# Altium schematic sheet Power.SchDoc
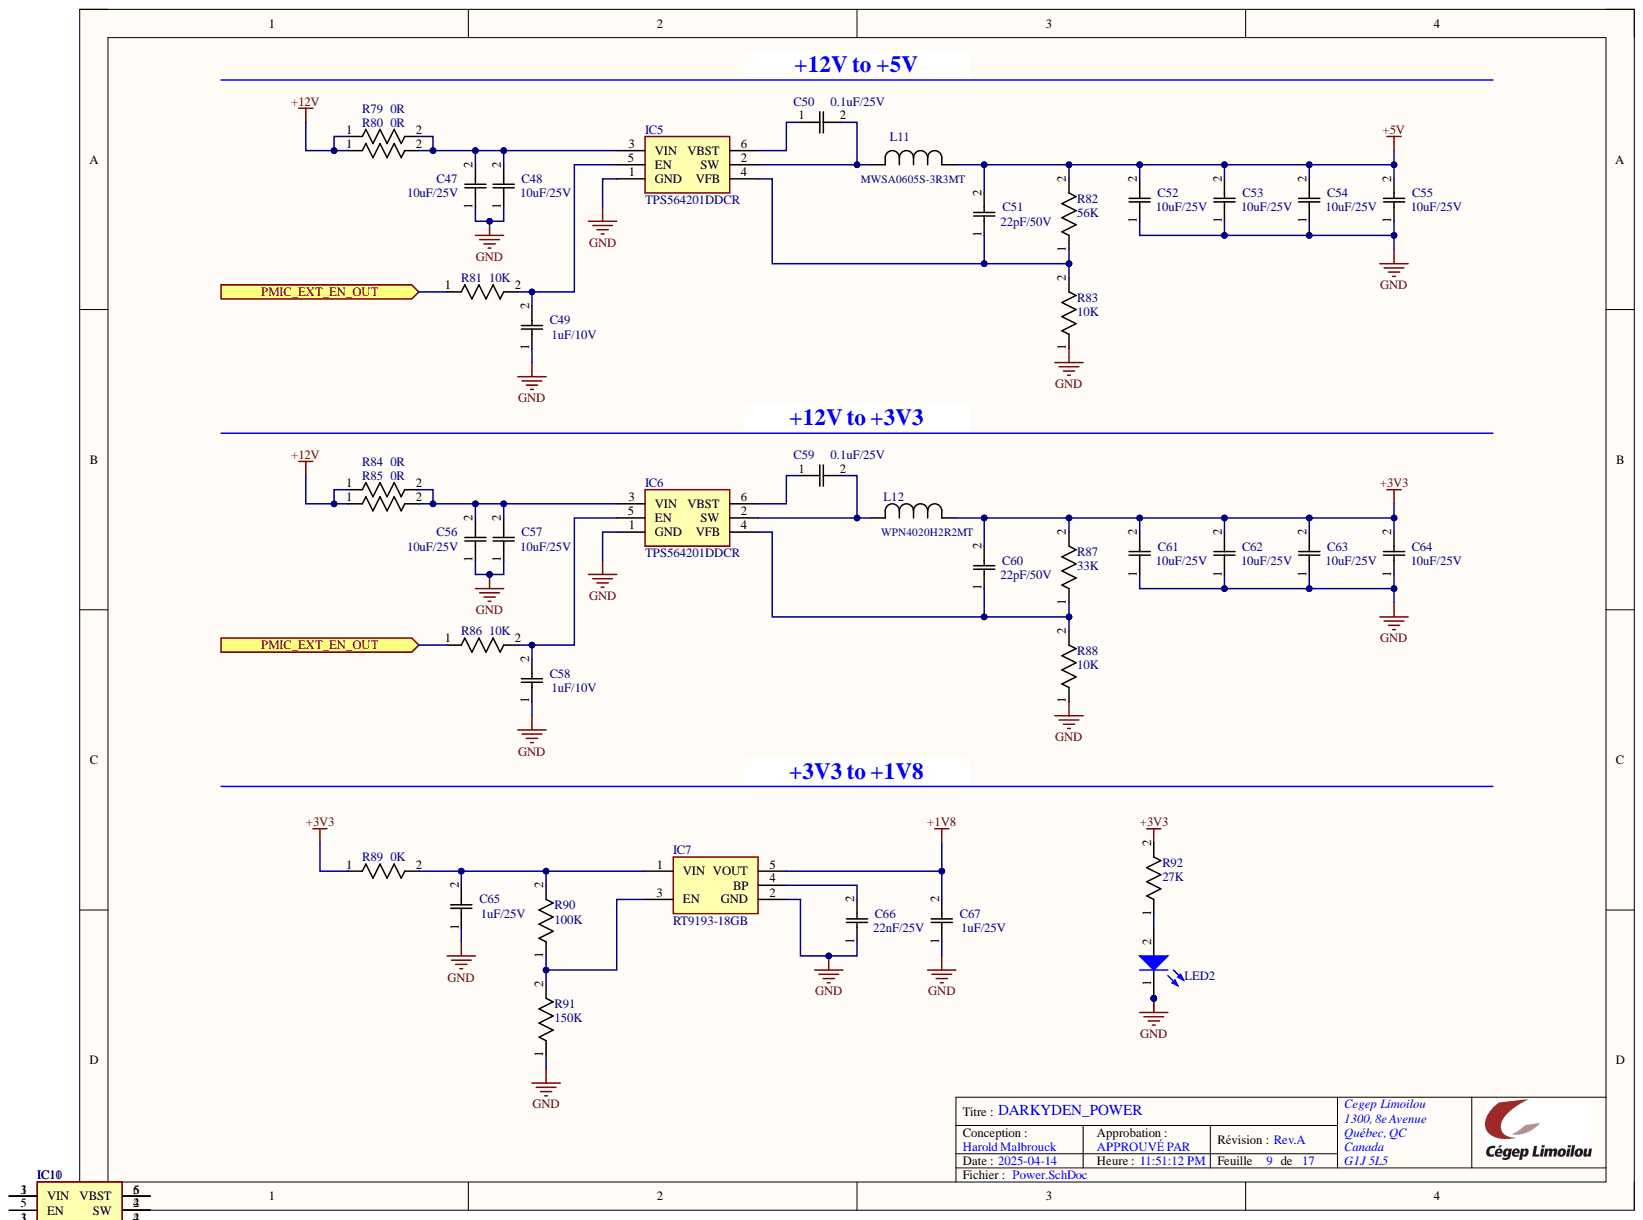
[diagram p0: Power.SchDoc]

## Parts
C47  10uF/25V  [CAP_CC0805_YAG]
C48  10uF/25V  [CAP_CC0805_YAG]
C49  1uF/10V  [CAP_CC0805_YAG]
C50  0.1uF/25V  [CAP_CC0805_YAG]
C51  22pF/50V  [CAP_CC0805_YAG]
C52  10uF/25V  [CAP_CC0805_YAG]
C53  10uF/25V  [CAP_CC0805_YAG]
C54  10uF/25V  [CAP_CC0805_YAG]
C55  10uF/25V  [CAP_CC0805_YAG]
C56  10uF/25V  [CAP_CC0805_YAG]
C57  10uF/25V  [CAP_CC0805_YAG]
C58  1uF/10V  [CAP_CC0805_YAG]
C59  0.1uF/25V  [CAP_CC0805_YAG]
C60  22pF/50V  [CAP_CC0805_YAG]
C61  10uF/25V  [CAP_CC0805_YAG]
C62  10uF/25V  [CAP_CC0805_YAG]
C63  10uF/25V  [CAP_CC0805_YAG]
C64  10uF/25V  [CAP_CC0805_YAG]
C65  1uF/25V  [CAP_CC0805_YAG]
C66  22nF/25V  [CAP_CC0805_YAG]
C67  1uF/25V  [CAP_CC0805_YAG]
IC5  TPS564201DDCR  [SOT95P280X110-6N]
IC6  TPS564201DDCR  [SOT95P280X110-6N]
IC7  RT9193-18GB  [SOT94P279X130-5N]
IC10  TPS564201DDCR  [SOT95P280X110-6N]
IC11  RT9193-18GB  [SOT94P279X130-5N]
L11  MWSA0605S-3R3MT  [IND_MWSA0603S_SNL]
L12  WPN4020H2R2MT  [IND_WPN4020H_SNL]
LED2  Blue, 3.2V, 140°  [WL-SMCD_0603]  (value not drawn)
R79  0R  [RES_0816_SUS]
R80  0R  [RES_0816_SUS]
R81  10K  [RES_0816_SUS]
R82  56K  [RES_0816_SUS]
R83  10K  [RES_0816_SUS]
R84  0R  [RES_0816_SUS]
R85  0R  [RES_0816_SUS]
R86  10K  [RES_0816_SUS]
R87  33K  [RES_0816_SUS]
R88  10K  [RES_0816_SUS]
R89  0K  [RES_0816_SUS]
R90  100K  [RES_0816_SUS]
R91  150K  [RES_0816_SUS]
R92  27K  [RES_0816_SUS]

## Ports
PMIC_EXT_EN_OUT

## Nets
+1V8: C67.2 IC7.5(VOUT)
+3V3: C60.2 C61.2 C62.2 C63.2 C64.2 L12.1 R87.2 R89.1 R92.2
+5V: C51.2 C52.2 C53.2 C54.2 C55.2 L11.1 R82.2
+12V: R79.1 R80.1 R84.1 R85.1
GND: C47.1 C48.1 C49.1 C52.1 C53.1 C54.1 C55.1 C56.1 C57.1 C58.1 C61.1 C62.1 C63.1 C64.1 C65.1 C66.1 C67.1 IC5.1(GND) IC6.1(GND) IC7.2(GND) LED2.1 R83.1 R88.1 R91.1
PMIC_EXT_EN_OUT: R81.1 R86.1 ; port PMIC_EXT_EN_OUT
NetC47_2: C47.2 C48.2 IC5.3(VIN) R79.2 R80.2
NetC49_2: C49.2 IC5.5(EN) R81.2
NetC50_1: C50.1 IC5.6(VBST)
NetC50_2: C50.2 IC5.2(SW) L11.2
NetC51_1: C51.1 IC5.4(VFB) R82.1 R83.2
NetC56_2: C56.2 C57.2 IC6.3(VIN) R84.2 R85.2
NetC58_2: C58.2 IC6.5(EN) R86.2
NetC59_1: C59.1 IC6.6(VBST)
NetC59_2: C59.2 IC6.2(SW) L12.2
NetC60_1: C60.1 IC6.4(VFB) R87.1 R88.2
NetC65_2: C65.2 IC7.1(VIN) R89.2 R90.2
NetC66_2: C66.2 IC7.4(BP)
NetIC7_3: IC7.3(EN) R90.1 R91.2
NetIC10_1: IC10.1 IC11.3
NetIC10_2: IC10.2(BP) IC11.4(BP)
NetIC10_3: IC10.3(VIN) IC11.1(VIN)
NetIC10_4: IC10.4 IC11.2
NetIC10_6: IC10.6(VOUT) IC11.5(VOUT)
NetLED2_2: LED2.2 R92.1

Not connected: IC10 5(EN)

## Library data of the parts
C47-C67 = Capacitor_0805: No Description Available; Mfr_Name: Yageo; Component Kind: Standard; Library Name: Capacitor_0805.SchLib
IC5 IC6 IC10 = TPS564201DDCR: Integrated Circuit; Manufacturer_Name: Texas Instruments; Arrow Part Number: TPS564201DDCR; Arrow Price/Stock: https://www.arrow.com/en/products/tps564201ddcr/texas-instruments?region=nac; Component Kind: Standard; Datasheet Link: http://www.ti.com/lit/gpn/tps564201; Height: 1.1mm; Library Name: SamacSys.SchLib; Manufacturer_Part_Number: TPS564201DDCR; Mouser Part Number: 595-TPS564201DDCR; Mouser Price/Stock: https://www.mouser.co.uk/ProductDetail/Texas-Instruments/TPS564201DDCR?qs=zEmsApcVOkUKQpyzRnH%2FcQ%3D%3D
IC7 IC11 = RT9193-18GB: Integrated Circuit; Manufacturer_Name: RICHTEK; Component Kind: Standard; Datasheet Link: http://www.richtek.com/assets/product_file/RT9193/DS9193-17.pdf; Height: 1.295mm; Library Name: SamacSys.SchLib; Manufacturer_Part_Number: RT9193-18GB; Mouser Part Number: 835-RT9193-18GB; Mouser Price/Stock: https://www.mouser.co.uk/ProductDetail/Richtek/RT9193-18GB?qs=amGC7iS6iy%2Fw9hCsuPB4cg%3D%3D
L11 = MWSA0603S-XRXMT: No Description Available; Mfr_Name: Sunlord; Component Kind: Standard; Library Name: MWSA0603S-XRXMT.IntLib
L12 = WPN4020H2R2MT: No Description Available; Mfr_Name: Sunlord; Type: Fixed Inductors; Component Kind: Standard; Library Name: WPN4020H2R2MT.IntLib
LED2 = BLUE: WL-SMCD Mono-color Chip LED Diffused, SMT, size 0603, Blue, 3.2V, 140°; Manufacturer: Wurth Elektronik; Manufacturer Part Number: 150060BS55040; Case/Size Code: 0603; Chip Technology: InGaN; Dominant Wavelength: 470nm; Luminous Intensity: 80mcd; Peak Wavelength: 465nm; Category: Signal LEDs; Component Kind: Standard; ComponentLink1Description: Website Link; ComponentLink1URL: https://www.we-online.com/redexpert/article/150060BS55040?ad; ComponentLink2Description: Datasheet Link; ComponentLink2URL: https://www.we-online.com/redexpert/spec/150060BS55040?ad; Emitting Color: Blue; Forward Voltage: 3.2V; Height: 0.4mm; Length: 1.6mm; Library Name: 0603_LED.SchLib; Match Code: WL-SMCD; Mount: Surface Mount; Operating Temperature Max: 85°C; Operating Temperature Min: -40°C; Packaging: Tape and Reel; Simulation: 0603_150060BS55040; Viewing Angle: 140°; Width: 0.8mm
R79-R92 = RG1608P-104-B-T5: No Description Available; Mfr_Name: Susumu; Component Kind: Standard; Library Name: RES_SMD_0603.SchLib
